# Altium schematic sheet FPGA_CFG.SchDoc(FPGA_CFG)
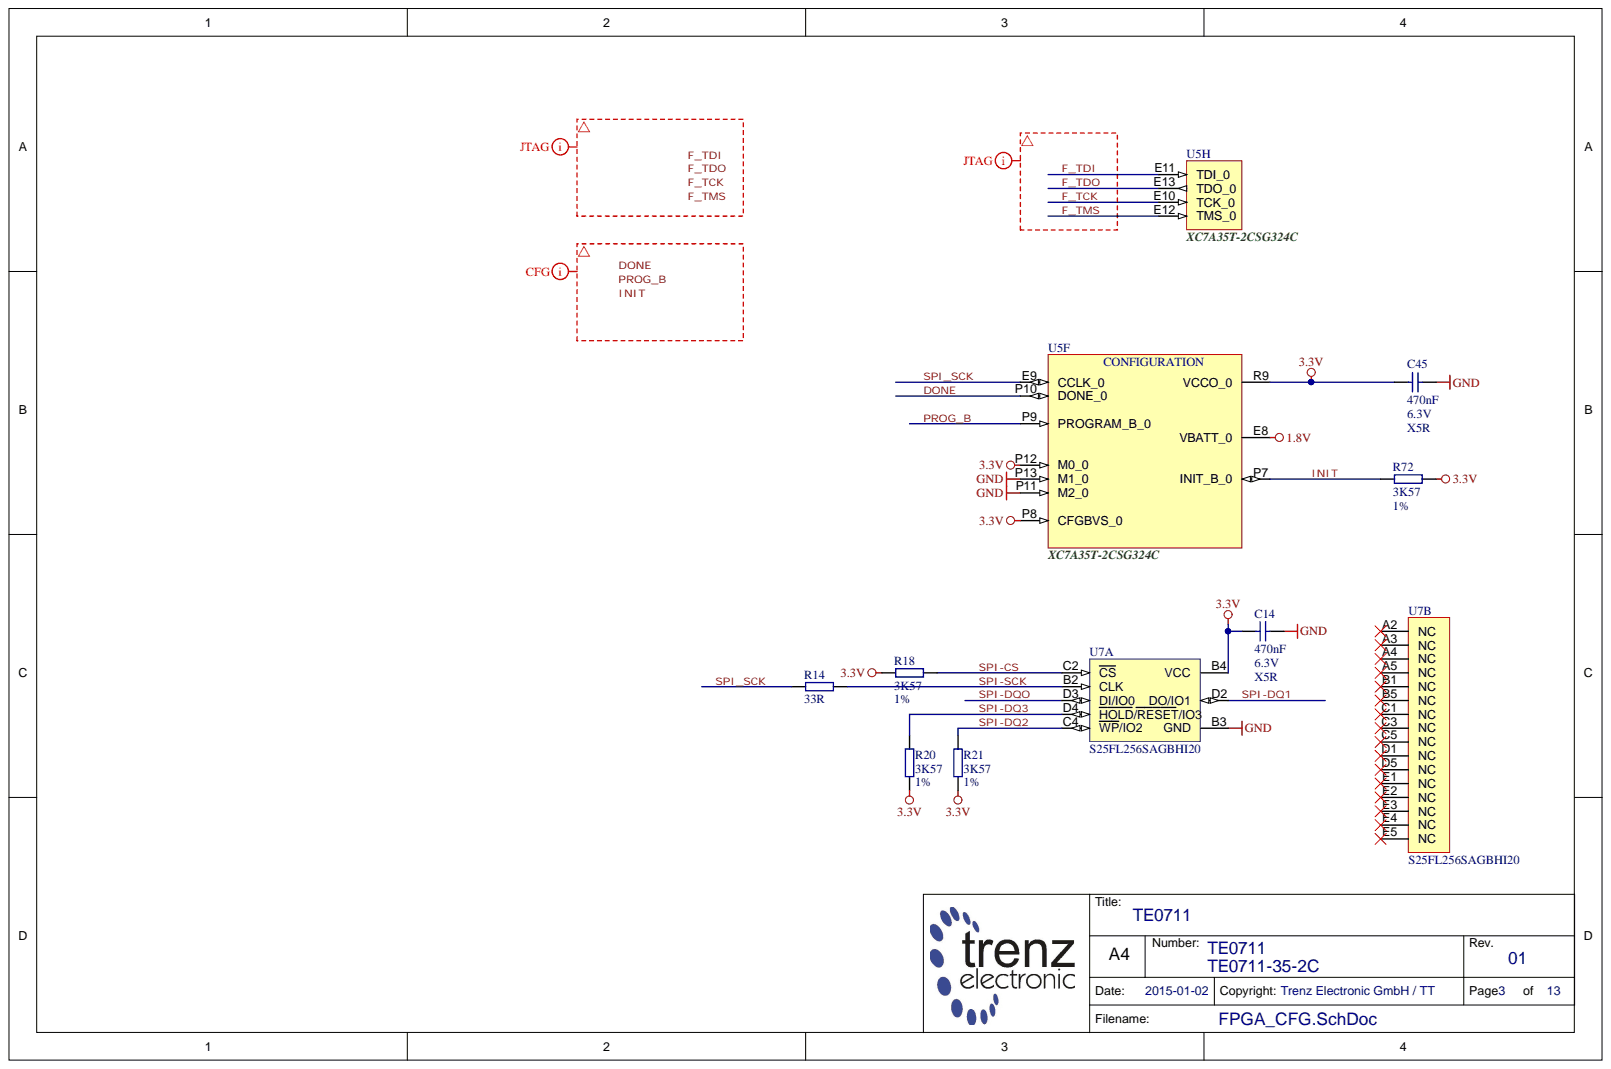
[diagram p0: FPGA_CFG.SchDoc]

## Parts
C14  470nF  Voltage: 6.3V  [CAPC0603X33N]
C45  470nF  Voltage: 6.3V  [CAPC0603X33N]
R14  33R  [RESC1005X40N]
R18  3K57  Tolerance: 1%  [RESC1005X40N]
R20  3K57  Tolerance: 1%  [RESC1005X40N]
R21  3K57  Tolerance: 1%  [RESC1005X40N]
R72  3K57  Tolerance: 1%  [RESC1005X40N]
U5  XC7A100T-2CSG324C  [BGA324C80P18X18_1500X1500X150N]  (value not drawn)  (parts F,H)
U7  S25FL256SAGBHI20  [BGA24C100P5X5_800X600X120N]  (parts A,B)

## Nets
1.8V: U5.E8(VBATT_0)
3.3V: C14.1 C45.2 R18.1 R20.2 R21.1 R72.2 U5.P8(CFGBVS_0) U5.P12(M0_0) U5.R9(VCCO_0) U7.B4(VCC)
GND: C14.2 C45.1 U5.P11(M2_0) U5.P13(M1_0) U7.B3(GND)
DONE: U5.P10(DONE_0)
F_TCK: U5.E10(TCK_0)
F_TDI: U5.E11(TDI_0)
F_TDO: U5.E13(TDO_0)
F_TMS: U5.E12(TMS_0)
INIT: R72.1 U5.P7(INIT_B_0)
PROG_B: U5.P9(PROGRAM_B_0)
SPI-CS: R18.2 U7.C2(CS)
SPI-DQ1: U7.D2(DO/IO1)
SPI-DQ2: R21.2 U7.C4(WP/IO2)
SPI-DQ3: R20.1 U7.D4(HOLD/RESET/IO3)
SPI-DQO: U7.D3(DI/IO0)
SPI-SCK: R14.2 U7.B2(CLK)
SPI_SCK: R14.1 U5.E9(CCLK_0)
N00449:
N00450:
N00451:

Not connected: U7 A2(NC) A3(NC) A4(NC) A5(NC) B1(NC) B5(NC) C1(NC) C3(NC) C5(NC) D1(NC) D5(NC) E1(NC) E2(NC) E3(NC) E4(NC) E5(NC)

## Library data of the parts
C14 C45 = 24921: KON 470nF X5R 6.3V SMD; Database Table Name: C_0603_0201; Mixed: SMT; Preferred: Yes; Tolerance: 20%; Value: 470n; AN: 24921; Case: 0603 (0201); Component Kind: Standard; Library Name: TE.DbLib; Technology: X5R
R14 = 22770: WID SMD 33R 1% 0,063W; Database Table Name: R_1005_0402; Mixed: SMT; Preferred: Yes; Value: 33; AN: 22770; Case: 1005 (0402); Component Kind: Standard; Library Name: TE.DbLib
R18 R20 R21 R72 = 23145: WID SMD 3K57 1% 0,063W; Database Table Name: R_1005_0402; Mixed: SMT; Value: 3.57K; AN: 23145; Case: 1005 (0402); Component Kind: Standard; Library Name: TE.DbLib
U5 = 24908: Database Table Name: PROG_LOGIC; Mixed: SMT; Trenz-Stock: Yes; AN: 24908; Case: CSG324; Component Kind: Standard; Description: XC7A100T-2CSG324C; Library Name: TE.DbLib; HelpURL: B:\AD\adlib\datasheet\XC7
U7 = 25945: Database Table Name: FLASH; Mixed: SMT; Preferred: Yes; AN: 25945; Case: TFBGA24; Component Kind: Standard; Description: S25FL256SAGBHI20; Library Name: TE.DbLib; Trenz-Stock: Yes; HelpURL: B:\AD\adlib\datasheet\S25FL256SAGNFI00
